# Altium schematic sheet Sheet1.SchDoc(Sheet1)
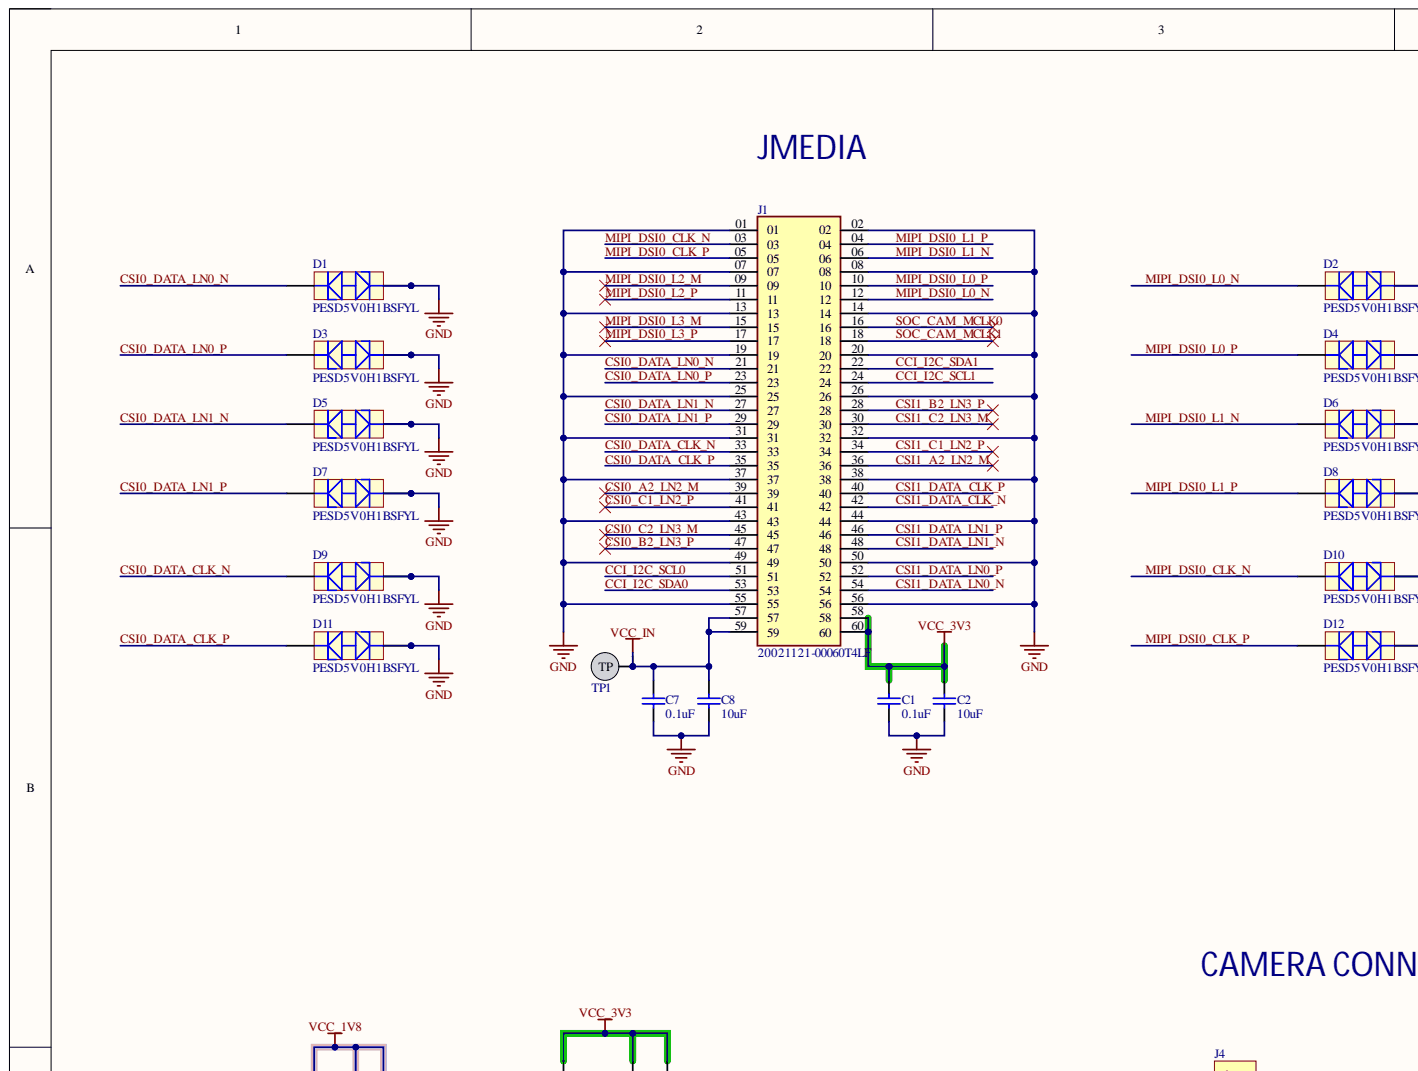
[diagram p0: Sheet1.SchDoc, top left]
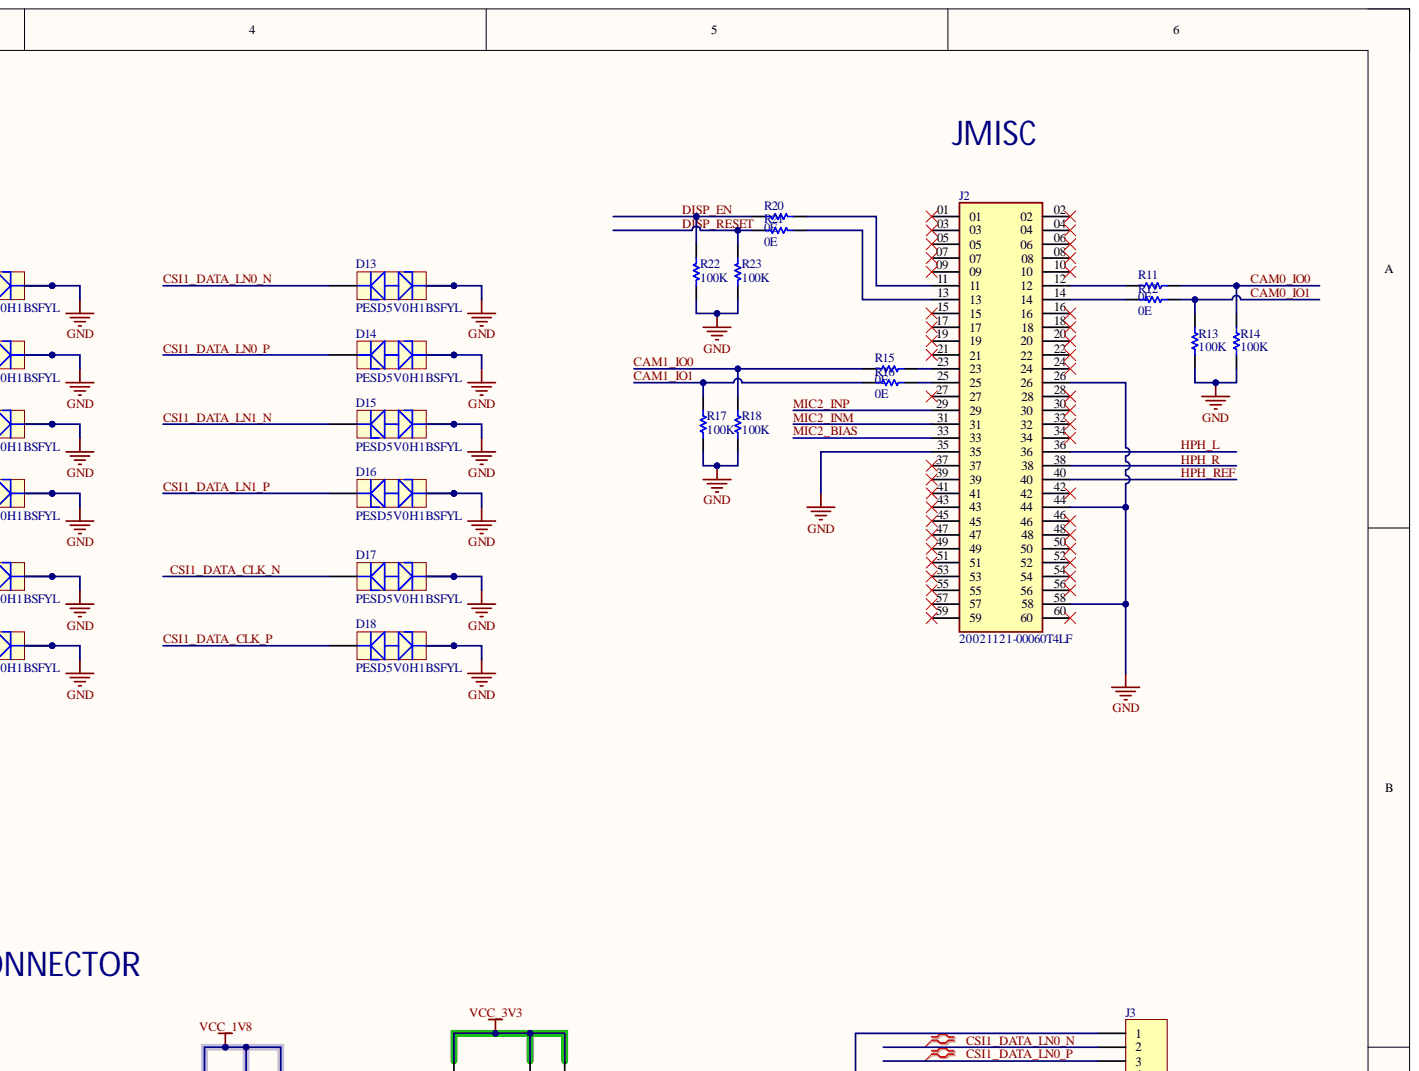
[diagram p1: Sheet1.SchDoc, top right]
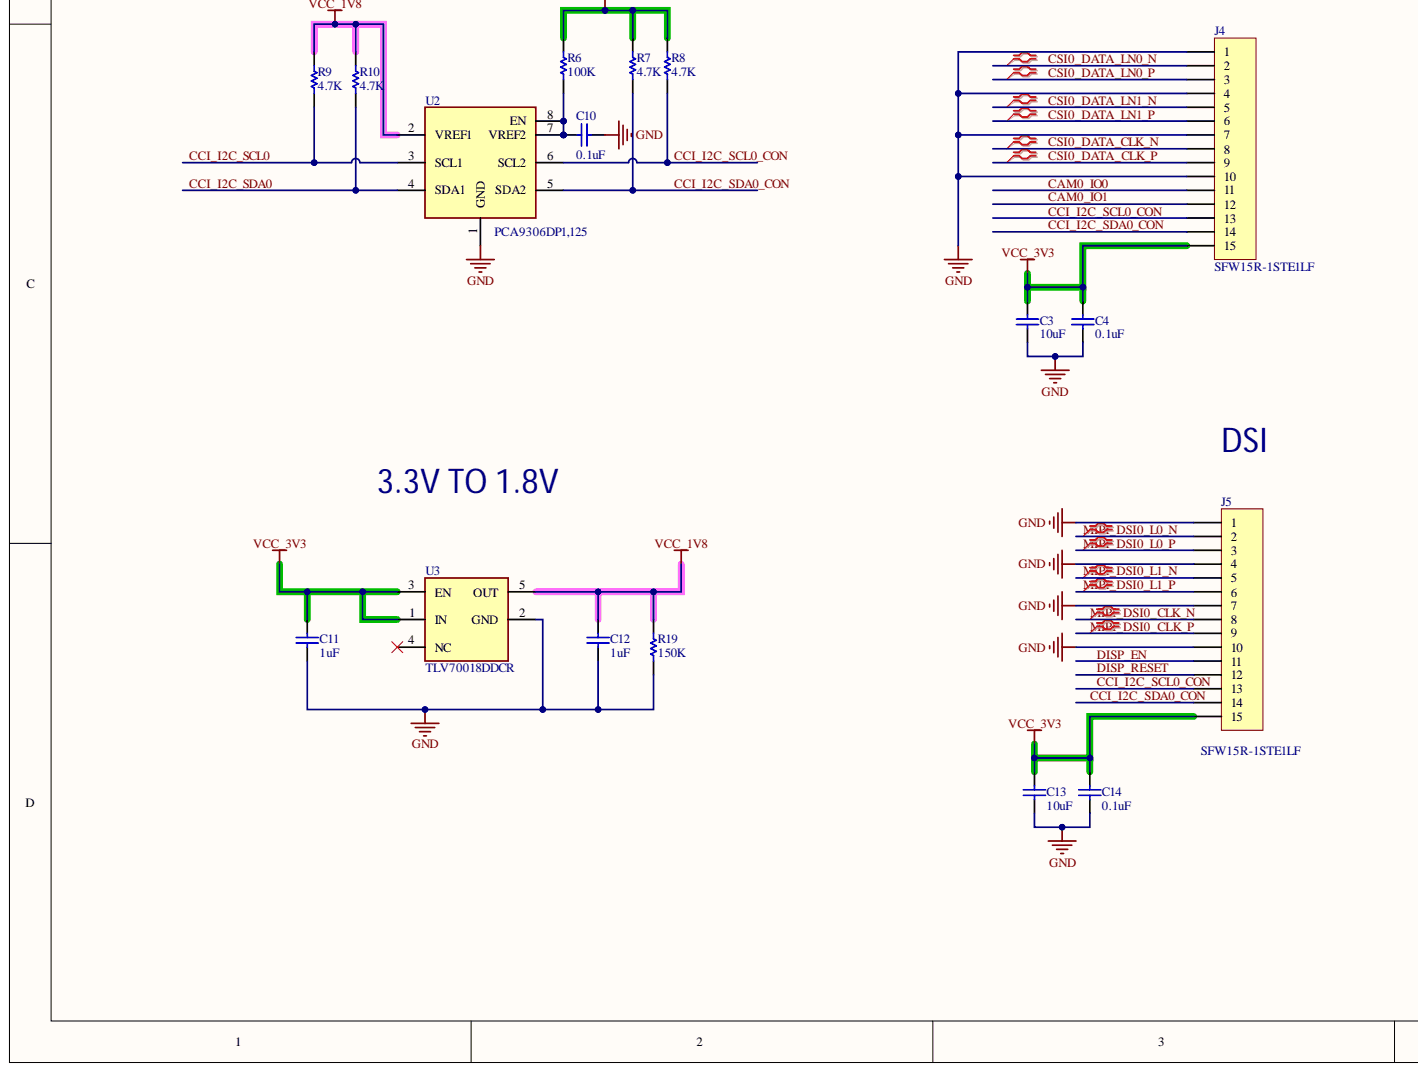
[diagram p2: Sheet1.SchDoc, bottom left]
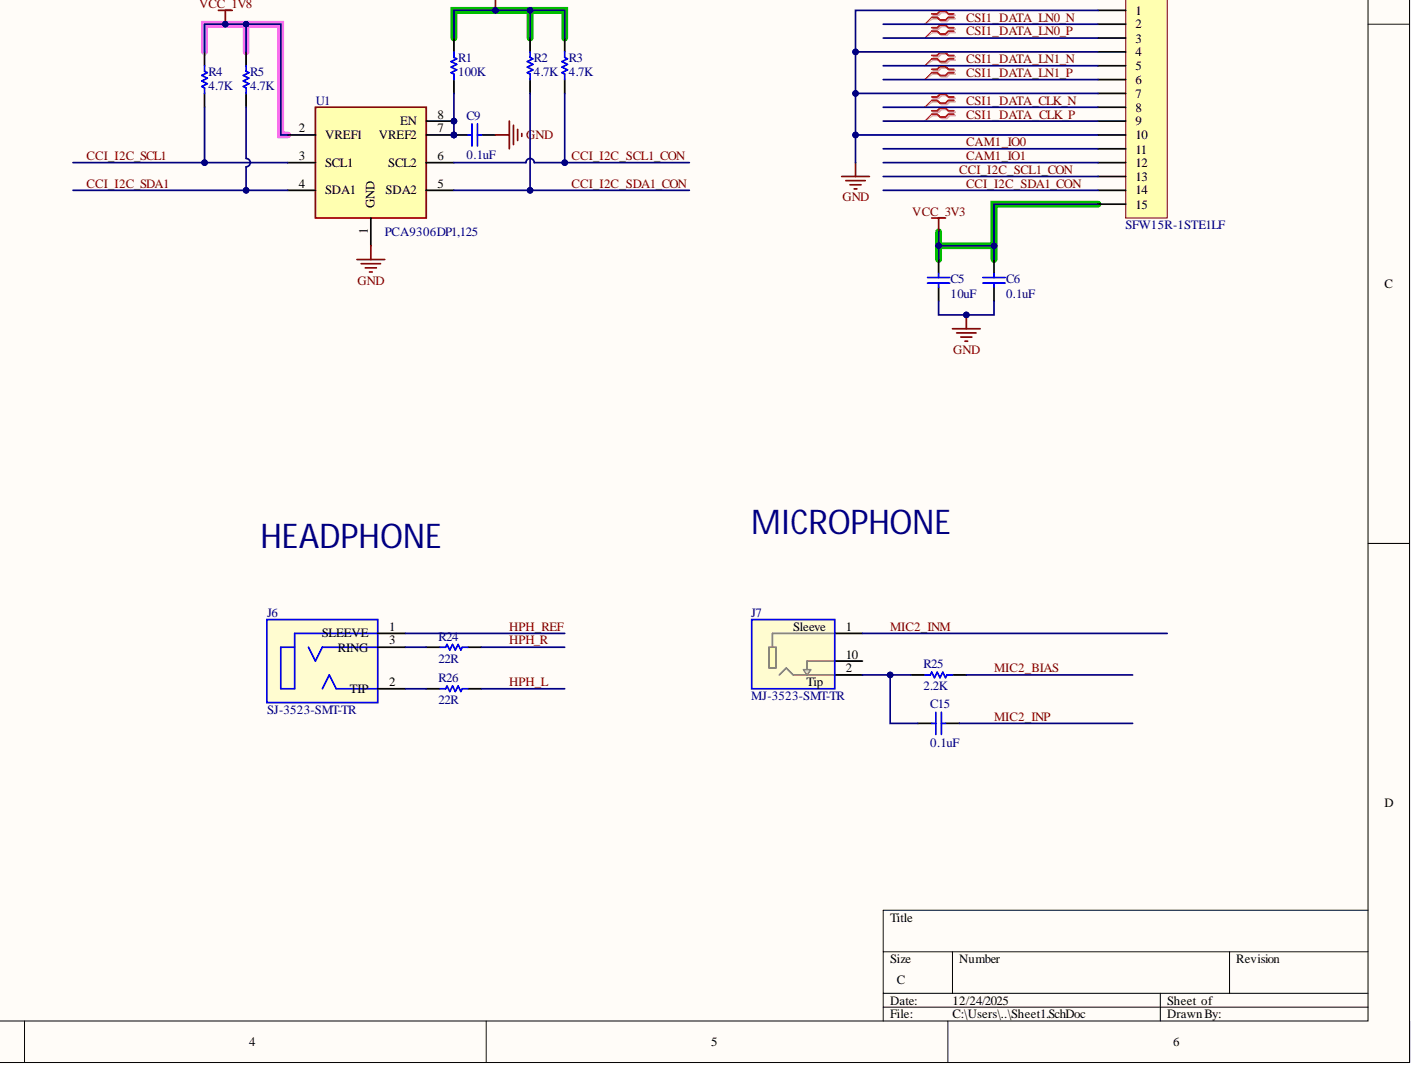
[diagram p3: Sheet1.SchDoc, bottom right]

## Parts
C1  0.1uF  [C0603R]
C2  10uF  [C0603R]
C3  10uF  [C0603R]
C4  0.1uF  [C0603R]
C5  10uF  [C0603R]
C6  0.1uF  [C0603R]
C7  0.1uF  [C0603R]
C8  10uF  [C0603R]
C9  0.1uF  [C0603R]
C10  0.1uF  [C0603R]
C11  1uF  [C0603R]
C12  1uF  [C0603R]
C13  10uF  [C0603R]
C14  0.1uF  [C0603R]
C15  0.1uF  [C0603R]
D1  PESD5V0H1BSFYL  [PESD5V0H1BSFYL_NEX]
D2  PESD5V0H1BSFYL  [PESD5V0H1BSFYL_NEX]
D3  PESD5V0H1BSFYL  [PESD5V0H1BSFYL_NEX]
D4  PESD5V0H1BSFYL  [PESD5V0H1BSFYL_NEX]
D5  PESD5V0H1BSFYL  [PESD5V0H1BSFYL_NEX]
D6  PESD5V0H1BSFYL  [PESD5V0H1BSFYL_NEX]
D7  PESD5V0H1BSFYL  [PESD5V0H1BSFYL_NEX]
D8  PESD5V0H1BSFYL  [PESD5V0H1BSFYL_NEX]
D9  PESD5V0H1BSFYL  [PESD5V0H1BSFYL_NEX]
D10  PESD5V0H1BSFYL  [PESD5V0H1BSFYL_NEX]
D11  PESD5V0H1BSFYL  [PESD5V0H1BSFYL_NEX]
D12  PESD5V0H1BSFYL  [PESD5V0H1BSFYL_NEX]
D13  PESD5V0H1BSFYL  [PESD5V0H1BSFYL_NEX]
D14  PESD5V0H1BSFYL  [PESD5V0H1BSFYL_NEX]
D15  PESD5V0H1BSFYL  [PESD5V0H1BSFYL_NEX]
D16  PESD5V0H1BSFYL  [PESD5V0H1BSFYL_NEX]
D17  PESD5V0H1BSFYL  [PESD5V0H1BSFYL_NEX]
D18  PESD5V0H1BSFYL  [PESD5V0H1BSFYL_NEX]
J1  20021121-00060T4LF  [SAMTEC_FTSH-130-01-L-DV-TR]
J2  20021121-00060T4LF  [SAMTEC_FTSH-130-01-L-DV-TR]
J3  SFW15R-1STE1LF  [AMPHENOL_SFW15R-1STE1LF]
J4  SFW15R-1STE1LF  [AMPHENOL_SFW15R-1STE1LF]
J5  SFW15R-1STE1LF  [AMPHENOL_SFW15R-1STE1LF]
J6  SJ-3523-SMT-TR  [CUI_SJ-3523-SMT-TR]
J7  MJ-3523-SMT-TR  [CONN_MJ-3523_CUD]
R1  100K  [R0603R]
R2  4.7K  [R0603R]
R3  4.7K  [R0603R]
R4  4.7K  [R0603R]
R5  4.7K  [R0603R]
R6  100K  [R0603R]
R7  4.7K  [R0603R]
R8  4.7K  [R0603R]
R9  4.7K  [R0603R]
R10  4.7K  [R0603R]
R11  0E  [R0603R]
R12  0E  [R0603R]
R13  100K  [R0603R]
R14  100K  [R0603R]
R15  0E  [R0603R]
R16  0E  [R0603R]
R17  100K  [R0603R]
R18  100K  [R0603R]
R19  150K  [R0603R]
R20  0E  [R0603R]
R21  0E  [R0603R]
R22  100K  [R0603R]
R23  100K  [R0603R]
R24  22R  [R0603R]
R25  2.2K  [R0603R]
R26  22R  [R0603R]
TP1  TEST_PAD  [PAD_TH_1.2MM_DRILL]  (value not drawn)
U1  PCA9306DP1,125  [SOP65P400X110-8N]
U2  PCA9306DP1,125  [SOP65P400X110-8N]
U3  TLV70018DDCR  [SOT95P280X110-5N]

## Nets
GND: C1.1 C2.1 C3.1 C4.1 C5.1 C6.1 C7.1 C8.1 C9.1 C10.1 C11.1 C12.1 C13.1 C14.1 D1.2 D2.2 D3.2 D4.2 D5.2 D6.2 D7.2 D8.2 D9.2 D10.2 D11.2 D12.2 D13.2 D14.2 D15.2 D16.2 D17.2 D18.2 J1.01 J1.02 J1.07 J1.08 J1.13 J1.14 J1.19 J1.20 J1.25 J1.26 J1.31 J1.32 J1.37 J1.38 J1.43 J1.44 J1.49 J1.50 J1.55 J1.56 J2.26 J2.35 J2.44 J2.58 J3.1 J3.4 J3.7 J3.10 J4.1 J4.4 J4.7 J4.10 J5.1 J5.4 J5.7 J5.10 R13.1 R14.1 R17.1 R18.1 R19.1 R22.1 R23.1 U1.1(GND) U2.1(GND) U3.2(GND)
VCC_1V8: C12.2 R4.2 R5.2 R9.2 R10.2 R19.2 U1.2(VREF1) U2.2(VREF1) U3.5(OUT)
VCC_3V3: C1.2 C2.2 C3.2 C4.2 C5.2 C6.2 C11.2 C13.2 C14.2 J1.58 J1.60 J3.15 J4.15 J5.15 R1.2 R2.2 R3.2 R6.2 R7.2 R8.2 U3.1(IN) U3.3(EN)
VCC_IN: C7.2 C8.2 J1.57 J1.59 TP1.1
CAM0_IO0: J4.11 R11.1 R14.2
CAM0_IO1: J4.12 R12.1 R13.2
CAM1_IO0: J3.11 R15.1 R18.2
CAM1_IO1: J3.12 R16.2 R17.2
CCI_I2C_SCL0: J1.51 R9.1 U2.3(SCL1)
CCI_I2C_SCL0_CON: J4.13 J5.13 R8.1 U2.6(SCL2)
CCI_I2C_SCL1: J1.24 R4.1 U1.3(SCL1)
CCI_I2C_SCL1_CON: J3.13 R3.1 U1.6(SCL2)
CCI_I2C_SDA0: J1.53 R10.1 U2.4(SDA1)
CCI_I2C_SDA0_CON: J4.14 J5.14 R7.1 U2.5(SDA2)
CCI_I2C_SDA1: J1.22 R5.1 U1.4(SDA1)
CCI_I2C_SDA1_CON: J3.14 R2.1 U1.5(SDA2)
CSI0_A2_LN2_M: J1.39
CSI0_B2_LN3_P: J1.47
CSI0_C1_LN2_P: J1.41
CSI0_C2_LN3_M: J1.45
CSI0_DATA_CLK_N: D9.1 J1.33 J4.8
CSI0_DATA_CLK_P: D11.1 J1.35 J4.9
CSI0_DATA_LN0_N: D1.1 J1.21 J4.2
CSI0_DATA_LN0_P: D3.1 J1.23 J4.3
CSI0_DATA_LN1_N: D5.1 J1.27 J4.5
CSI0_DATA_LN1_P: D7.1 J1.29 J4.6
CSI1_A2_LN2_M: J1.36
CSI1_B2_LN3_P: J1.28
CSI1_C1_LN2_P: J1.34
CSI1_C2_LN3_M: J1.30
CSI1_DATA_CLK_N: D17.1 J1.42 J3.8
CSI1_DATA_CLK_P: D18.1 J1.40 J3.9
CSI1_DATA_LN0_N: D13.1 J1.54 J3.2
CSI1_DATA_LN0_P: D14.1 J1.52 J3.3
CSI1_DATA_LN1_N: D15.1 J1.48 J3.5
CSI1_DATA_LN1_P: D16.1 J1.46 J3.6
DISP_EN: J5.11 R20.1 R22.2
DISP_RESET: J5.12 R21.1 R23.2
HPH_L: J2.36 R26.1
HPH_R: J2.38 R24.1
HPH_REF: J2.40 J6.1
MIC2_BIAS: J2.33 R25.1
MIC2_INM: J2.31 J7.1
MIC2_INP: C15.1 J2.29
MIPI_DSI0_CLK_N: D10.1 J1.03 J5.8
MIPI_DSI0_CLK_P: D12.1 J1.05 J5.9
MIPI_DSI0_L0_N: D2.1 J1.12 J5.2
MIPI_DSI0_L0_P: D4.1 J1.10 J5.3
MIPI_DSI0_L1_N: D6.1 J1.06 J5.5
MIPI_DSI0_L1_P: D8.1 J1.04 J5.6
MIPI_DSI0_L2_M: J1.09
MIPI_DSI0_L2_P: J1.11
MIPI_DSI0_L3_M: J1.15
MIPI_DSI0_L3_P: J1.17
SOC_CAM_MCLK0: J1.16
SOC_CAM_MCLK1: J1.18
NetC9_2: C9.2 R1.1 U1.7(VREF2) U1.8(EN)
NetC10_2: C10.2 R6.1 U2.7(VREF2) U2.8(EN)
NetC15_2: C15.2 J7.2 R25.2
NetJ2_11: J2.11 R20.2
NetJ2_12: J2.12 R11.2
NetJ2_13: J2.13 R21.2
NetJ2_14: J2.14 R12.2
NetJ2_23: J2.23 R15.2
NetJ2_25: J2.25 R16.1
NetJ6_2: J6.2 R26.2
NetJ6_3: J6.3 R24.2

Not connected: J2 01 02 03 04 05 06 07 08 09 10 15 16 17 18 19 20 21 22 24 27 28 30 32 34 37 39 41 42 43 45 46 47 48 49 50 51 52 53 54 55 56 57 59 60; J7 10; U3 4(NC)

## Library data of the parts
C1-C15 = Capacitor ceramic: Component Kind: Standard; Description: Capacitor ceramic; Signal Integrity: Cap; Simulation: CAP; Library Name: EASETECH.SchLib
D1-D18 = PESD5V0H1BSFYL: 5V Clamp 7A (8/20µs) Ipp Tvs Diode Surface Mount DSN0603-2; MF: Nexperia USA Inc.; Check_prices: https://www.snapeda.com/parts/PESD5V0H1BSFYL/Nexperia/view-part/?ref=eda; Component Kind: Standard; Library Name: PESD5V0H1BSFYL.SchLib; MP: PESD5V0H1BSFYL; Package: 0201 Nexperia USA; SnapEDA_Link: https://www.snapeda.com/parts/PESD5V0H1BSFYL/Nexperia/view-part/?ref=snap
J1 J2 = FTSH-130-01-L-DV-TR: MF: Samtec; Availability: Unavailable; Component Kind: Standard; Library Name: FTSH-130-01-L-DV-TR.SchLib; MP: FTSH-130-01-L-DV-TR; Package: None; Price: None
J3-J5 = Header 15: Header, 15-Pin; Component Kind: Standard; LatestRevisionDate: 17-Jul-2002; LatestRevisionNote: Re-released for DXP Platform.; Library Name: Miscellaneous Connectors.IntLib; Signal Integrity: Connector; Publisher: Altium Limited
J6 = SJ-3523-SMT-TR: 3.5 mm, Stereo, Right Angle, Surface Mount (SMT), 3 Conductors, 0 Internal Switches, Audio Jack Connector; MF: Same Sky; Check_prices: https://www.snapeda.com/parts/SJ-3523-SMT-TR/Same+Sky/view-part/?ref=eda; Component Kind: Standard; Library Name: SJ-3523-SMT-TR.SchLib; MP: SJ-3523-SMT-TR; Package: None; SnapEDA_Link: https://www.snapeda.com/parts/SJ-3523-SMT-TR/Same+Sky/view-part/?ref=snap
J7 = MJ-3523-SMT-TR: No Description Available; Manufacturer_Name: Same Sky; Component Kind: Standard; Library Name: MJ-3523-SMT-TR.SchLib; Manufacturer_Part_Number: MJ-3523-SMT-TR; RefDes: RefDes; Type: Type; Copyright: Copyright (C) 2025 Ultra Librarian. All rights reserved.
R1 R6 R11-R26 = Res1: Resistor; Value: 4.7K; Component Kind: Standard; LatestRevisionDate: 17-Jul-2002; LatestRevisionNote: Re-released for DXP Platform.; Library Name: Miscellaneous Devices.IntLib; PackageDescription: Axial Device, Thru-Hole; 2 Leads; 0.3 in Pin Spacing; PackageReference: AXIAL-0.3; Published: 8-Jun-2000; Signal Integrity: Res; Simulation: RESISTOR; Publisher: Altium Limited
R2-R5 R7-R10 = Res1: Resistor; Component Kind: Standard; LatestRevisionDate: 17-Jul-2002; LatestRevisionNote: Re-released for DXP Platform.; Library Name: Miscellaneous Devices.IntLib; PackageDescription: Axial Device, Thru-Hole; 2 Leads; 0.3 in Pin Spacing; PackageReference: AXIAL-0.3; Published: 8-Jun-2000; Signal Integrity: Res; Simulation: RESISTOR; Value: 4.7K; Publisher: Altium Limited
U1 U2 = PCA9306DP1,125: Voltage Level Translator Bidirectional 1 Circuit 2 Channel - 8-TSSOP; MF: NXP USA; Check_prices: https://www.snapeda.com/parts/PCA9306DP1,125/NXP+Semiconductors/view-part/?ref=eda; Component Kind: Standard; Library Name: PCA9306DP1,125.SchLib; MP: PCA9306DP1,125; Package: TSSOP-8 NXP Semiconductors; SnapEDA_Link: https://www.snapeda.com/parts/PCA9306DP1,125/NXP+Semiconductors/view-part/?ref=snap
U3 = TLV70018DDCR: 200-mA, high-PSRR, low-IQ, low-dropout voltage regulator with enable 5-SOT-23-THIN -40 to 125; MF: Texas Instruments; Availability: Warning; Check_prices: https://www.snapeda.com/parts/TLV70018DDCR/Texas%20Instruments/view-part/46473/?ref=eda; Component Kind: Standard; Library Name: TLV70018DDCR.SchLib; MP: TLV70018DDCR; Package: SOT-23-THN-5 Texas Instruments; Price: None; Purchase-URL: https://pricing.snapeda.com/search/part/TLV70018DDCR/?ref=eda; SnapEDA_Link: https://www.snapeda.com/parts/TLV70018DDCR/Texas%20Instruments/view-part/46473/?ref=snap
TP1 = TEST_PAD: Component Kind: Standard; Library Name: EASETECH.SchLib
